# Altium schematic sheet silnik.SchDoc(silnik)
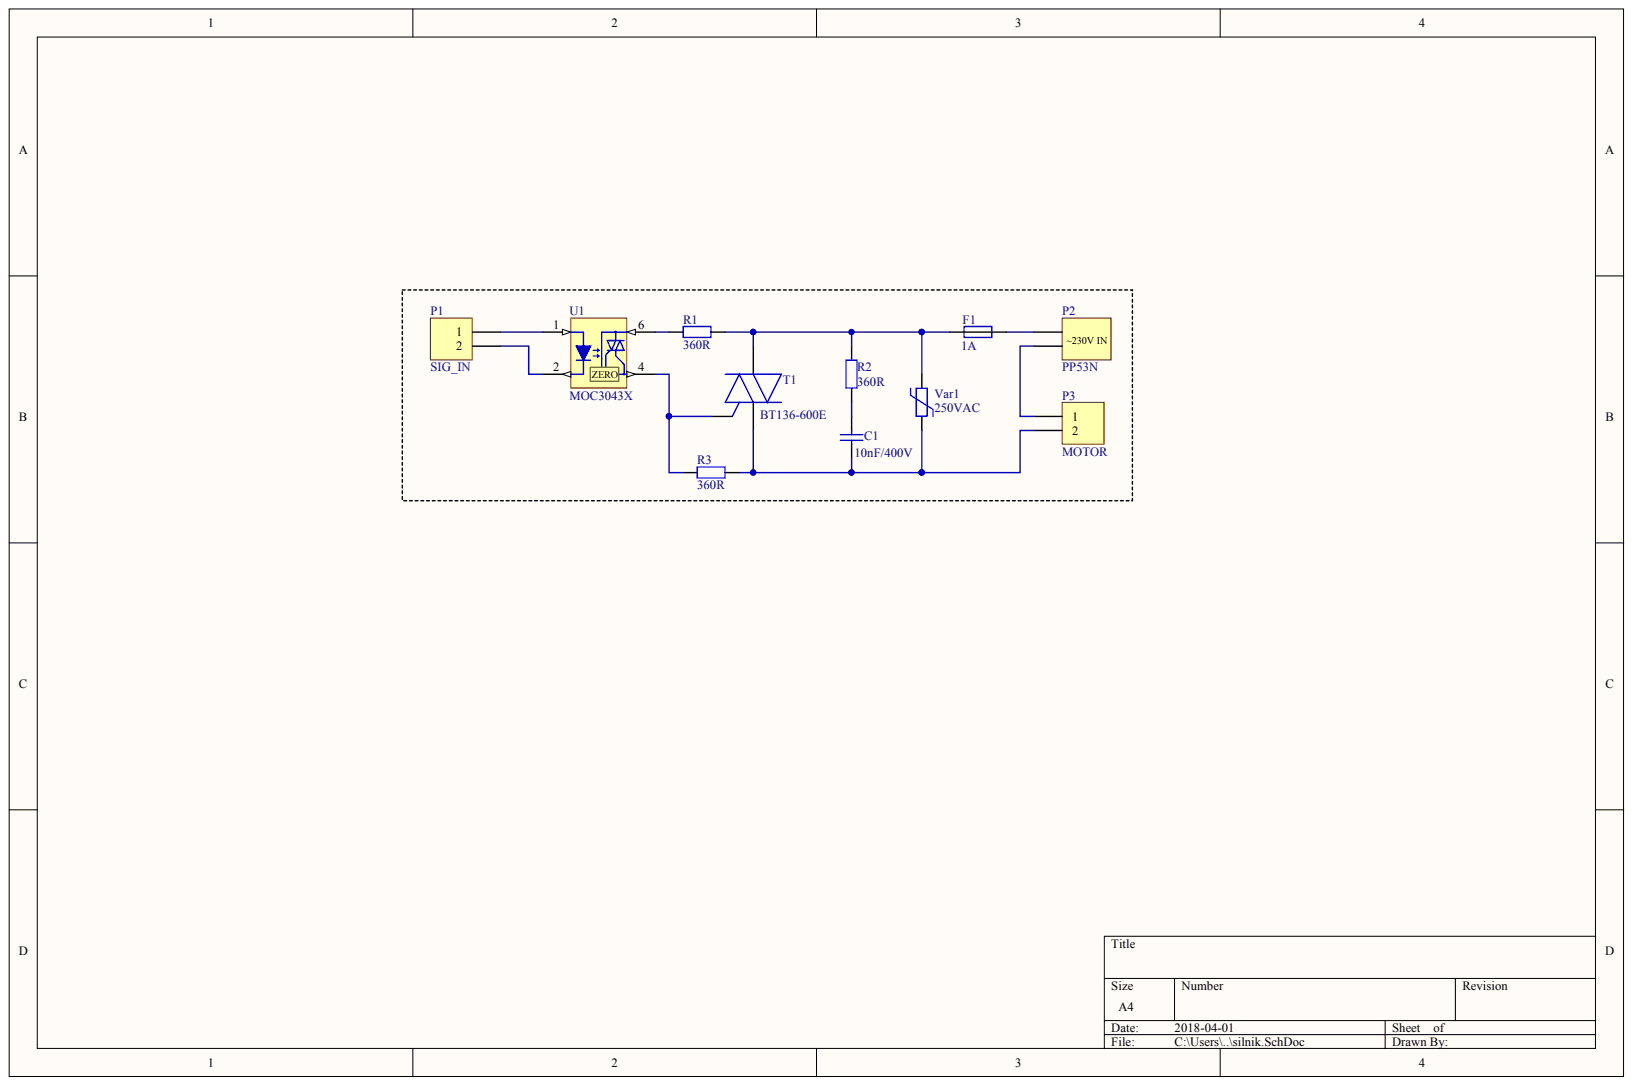
[diagram p0: silnik.SchDoc]

## Parts
C1  10nF/400V
F1  1A
P1  SIG_IN
P2  PP53N
P3  MOTOR
R1  360R
R2  360R
R3  360R
T1  BT136-600E
U1  MOC3043X
Var1  250VAC

## Nets
NetC1_1: C1.1 R2.1
NetC1_2: C1.2 P3.2 R3.2 T1.1 Var1.2
NetF1_1: F1.1 R1.2 R2.2 T1.2 Var1.1
NetF1_2: F1.2 P2.2
NetP1_1: P1.1 U1.1
NetP1_2: P1.2 U1.2
NetP2_1: P2.1 P3.1
NetR1_1: R1.1 U1.6
NetR3_1: R3.1 T1.3 U1.4(ZERO)
